# Altium schematic sheet I2C_Display.SchDoc
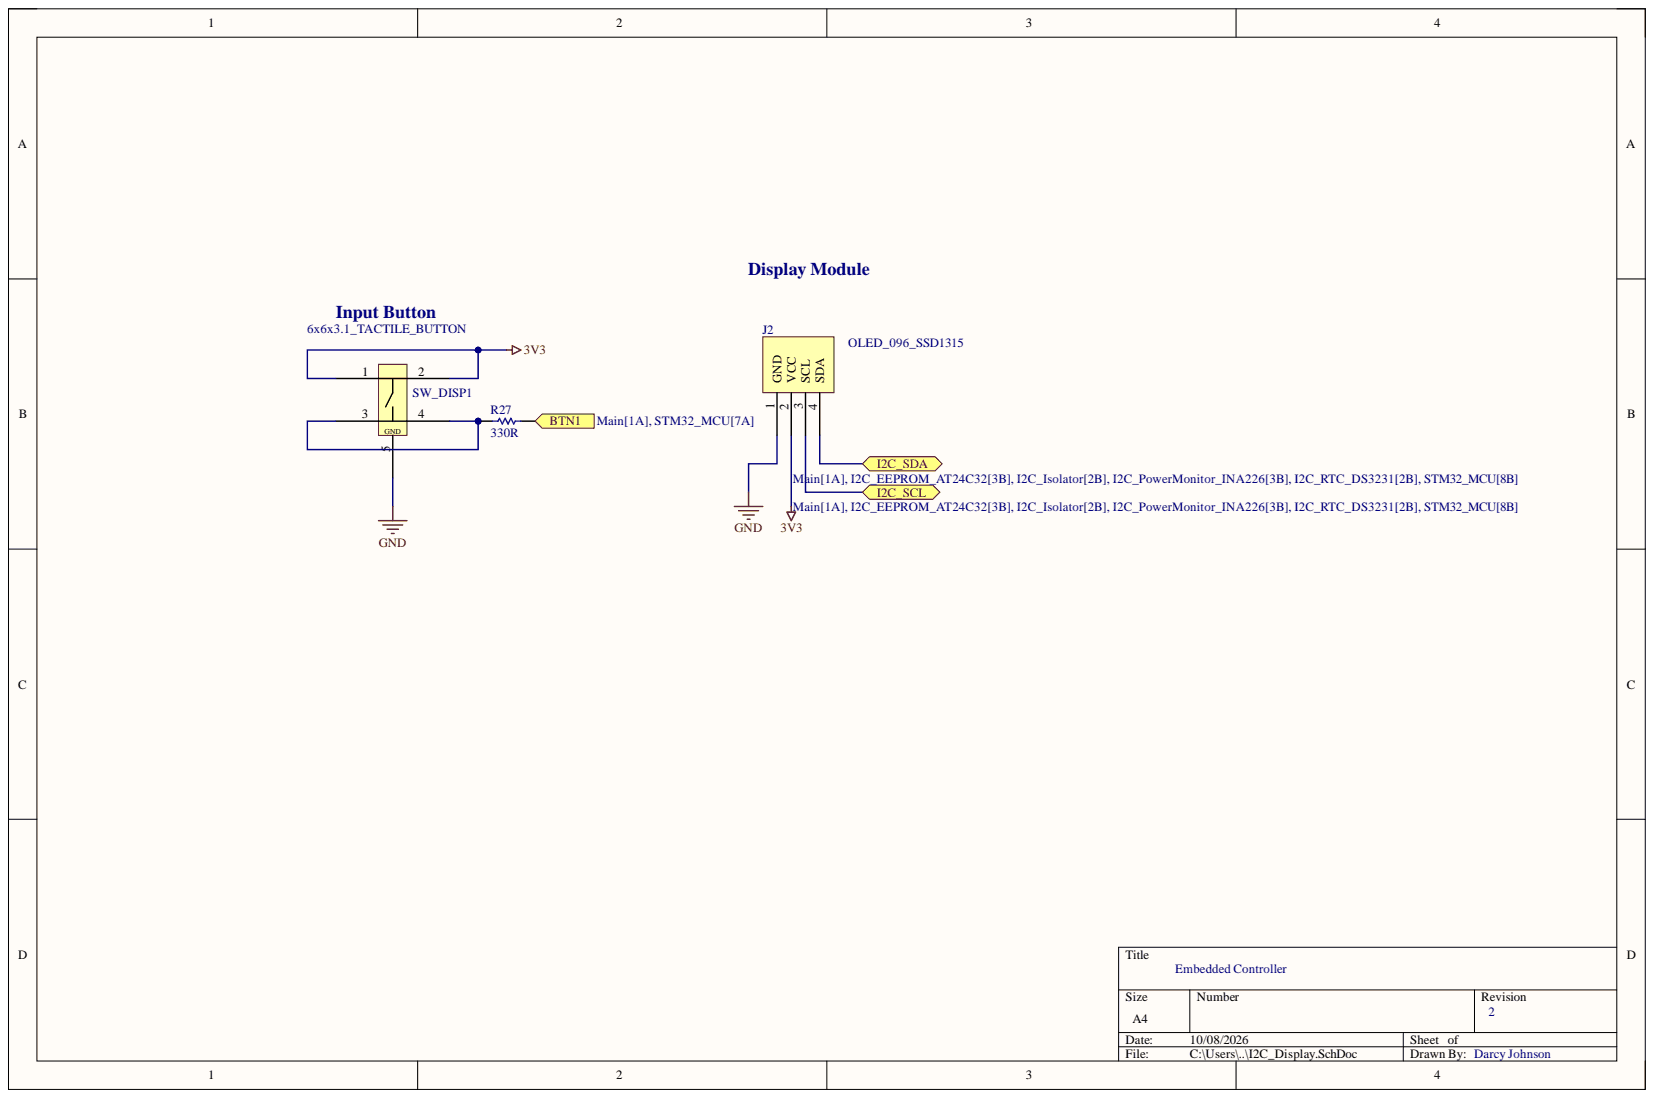
[diagram p0: I2C_Display.SchDoc]

## Parts
J2  OLED_096_SSD1315  [OLED_096_SSD1315]
R27  330R  [RESC1608X55X30LL15T15]
SW_DISP1  6x6x3.1_TACTILE_BUTTON  [6x6x3.1_TACTILE_BUTTON]

## Ports
BTN1, I2C_SCL, I2C_SDA

## Nets
3V3: J2.2(VCC) SW_DISP1.1 SW_DISP1.2
GND: J2.1(GND) SW_DISP1.5
BTN1: R27.2 ; port BTN1
I2C_SCL: J2.3(SCL) ; port I2C_SCL
I2C_SDA: J2.4(SDA) ; port I2C_SDA
NetR27_1: R27.1 SW_DISP1.3 SW_DISP1.4

## Library data of the parts
R27 = CMP-2000-00435-2: Chip Resistor, 330 Ohm, +/- 1%, 0.1 W, -55 to 155 degC, 0603 (1608 Metric), RoHS, Tape and Reel; Current Rating: 500 mA; Gender: Male; Mating Cycles: 10000; Voltage Rating: 10 V; Component Kind: Standard; ComponentLink1Description: Manufacturer URL; ComponentLink1URL: http://www.panasonic.com/; ComponentLink2Description: Datasheet; ComponentLink2URL: http://industrial.panasonic.com/cdbs/www-data/pdf/RDA0000/AOA0000C86.pdf; Contact Finish: Gold; Contact Material: Copper; Contact Plating: Gold; DatasheetVersion: Apr-15; Height: 1.45 mm; Lead Pitch: 1.1 mm; Length: 13.7 mm; Library Name: Altium Content Vault; Max Operating Temperature: 85 degC; Min Operating Temperature: -25 degC; Mount: Surface Mount; Package Quantity: 1500; PackageDescription: 2-Pin Surface Mount Device, Body 1.6 x 0.85 mm; PackageReference: 0603; Packaging: Tape and Reel; Pins: 8; REACH SVHC: No SVHC; RoHSCompliant: true; Width: 1.45 mm
J2 = OLED_096_SSD1315: Component Kind: Standard; Library Name: OLED_096_SSD1315.SchLib
SW_DISP1 = 6x6x3.1_TACTILE_BUTTON: Component Kind: Standard; Library Name: 6x6x3.1_TACTILE_BUTTON.SchLib
